# Altium schematic sheet main.SchDoc
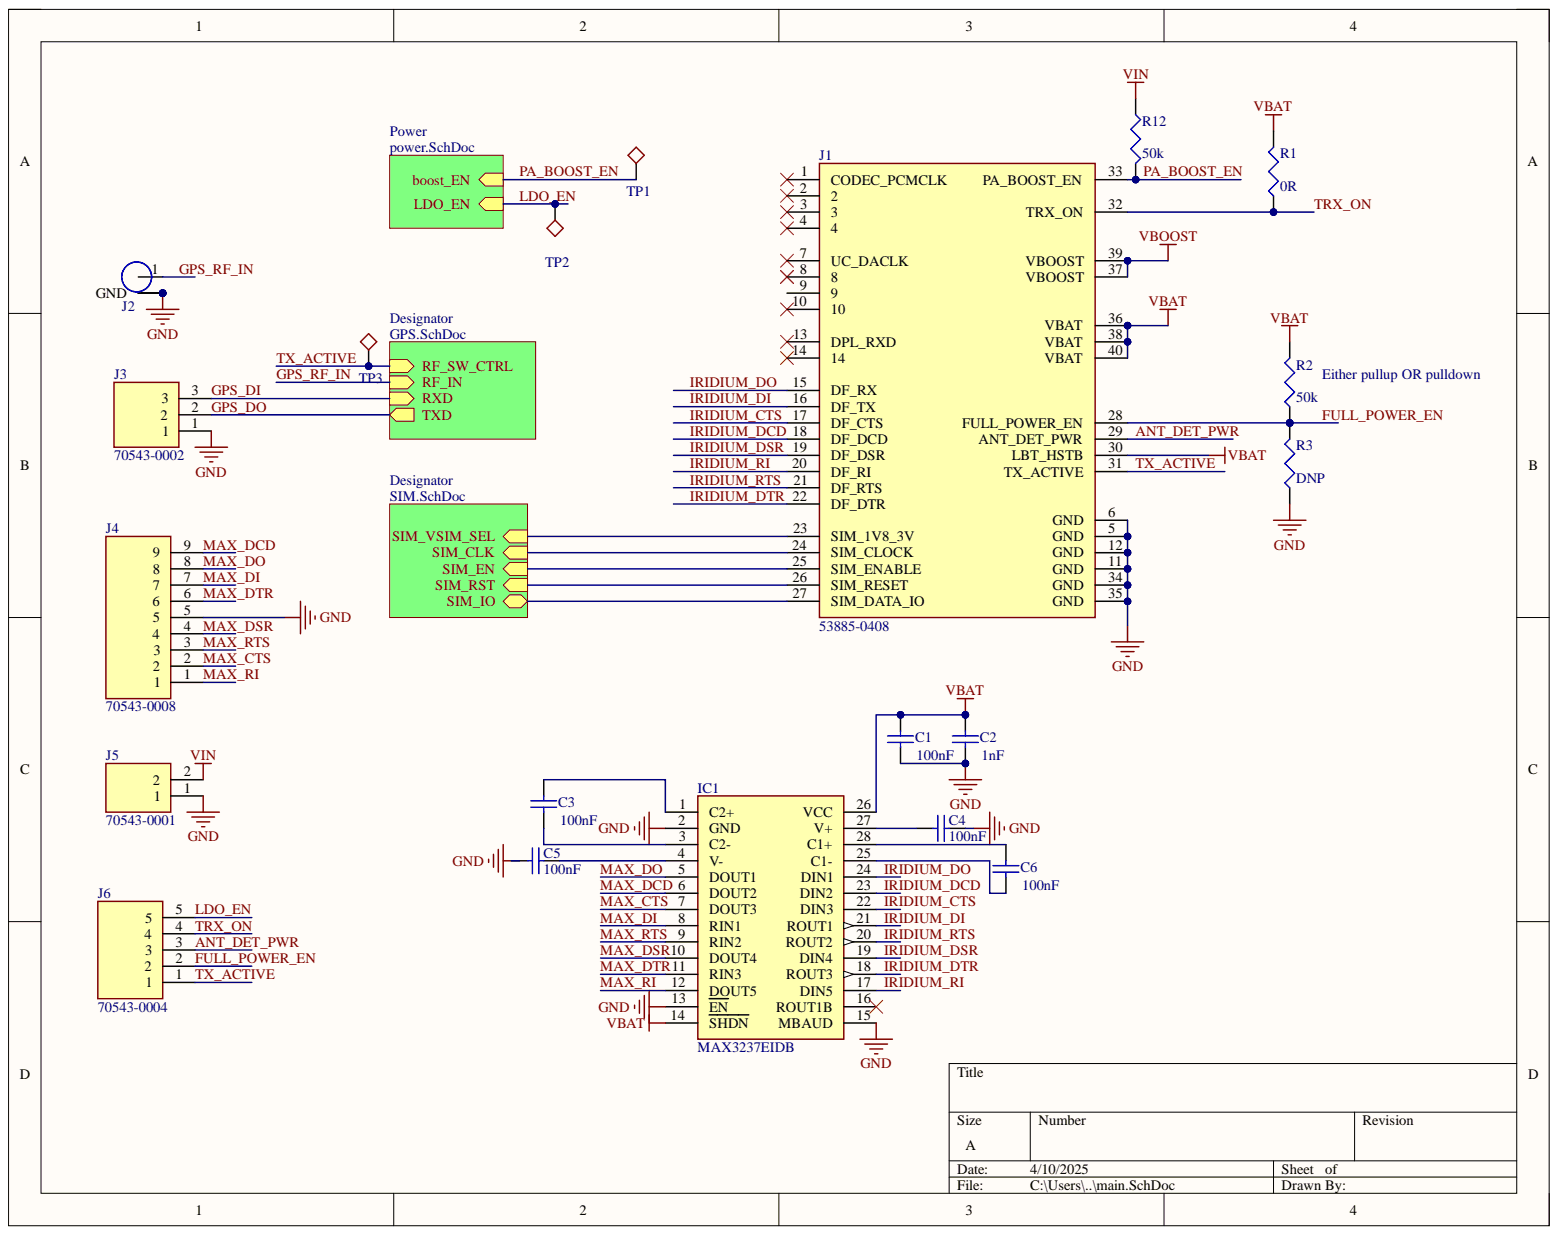
[diagram p0: main.SchDoc]

## Parts
C1  Capacitance: 100nF  [CAPC1608X90X35ML10T15]
C2  Capacitance: 1nF  [CAPC1608X90X35ML10T15]
C3  Capacitance: 100nF  [CAPC1608X90X35ML10T15]
C4  Capacitance: 100nF  [CAPC1608X90X35ML10T15]
C5  Capacitance: 100nF  [CAPC1608X90X35ML10T15]
C6  Capacitance: 100nF  [CAPC1608X90X35ML10T15]
IC1  MAX3237EIDB  [SOP65P780X200-28N]
J1  53885-0408  [538850408]
J2  SMA  [edge_mount_sma]  (value not drawn)
J3  70543-0002  [RHDR3W64P0X254_1X3_1017X660X1194P]
J4  70543-0008  [SHDR9W64P0X254_1X9_2541X660X1181P]
J5  70543-0001  [SHDR2W64P0X254_1X2_737X660X1181P]
J6  70543-0004  [SHDR5W64P0X254_1X5_1525X660X1181P]
R1  value 0R  [J1-0603]
R2  value 50k  [J1-0603]
R3  value DNP  [J1-0603]
R12  value 50k  [J1-0603]
TP1  tp15  [TP15]  (value not drawn)
TP2  tp15  [TP15]  (value not drawn)
TP3  tp15  [TP15]  (value not drawn)

## Nets
GND: C1.1 C2.1 C4.1 C5.2 IC1.2(GND) IC1.13(EN) IC1.15(MBAUD) J1.5(GND) J1.6(GND) J1.11(GND) J1.12(GND) J1.34(GND) J1.35(GND) J2.2 J2.3 J2.4 J2.5 J3.1 J4.5 J5.1 R3.1
VBAT: C1.2 C2.2 IC1.14(SHDN) IC1.26(VCC) J1.30(LBT_HSTB) J1.36(VBAT) J1.38(VBAT) J1.40(VBAT) R1.2 R2.2
VBOOST: J1.37(VBOOST) J1.39(VBOOST)
VIN: J5.2 R12.1
ANT_DET_PWR: J1.29(ANT_DET_PWR) J6.3
FULL_POWER_EN: J1.28(FULL_POWER_EN) J6.2 R2.1 R3.2
GPS_DI: J3.3
GPS_DO: J3.2
GPS_RF_IN: J2.1
IRIDIUM_CTS: IC1.22(DIN3) J1.17(DF_CTS)
IRIDIUM_DCD: IC1.23(DIN2) J1.18(DF_DCD)
IRIDIUM_DI: IC1.21(ROUT1) J1.16(DF_TX)
IRIDIUM_DO: IC1.24(DIN1) J1.15(DF_RX)
IRIDIUM_DSR: IC1.19(DIN4) J1.19(DF_DSR)
IRIDIUM_DTR: IC1.18(ROUT3) J1.22(DF_DTR)
IRIDIUM_RI: IC1.17(DIN5) J1.20(DF_RI)
IRIDIUM_RTS: IC1.20(ROUT2) J1.21(DF_RTS)
LDO_EN: J6.5 TP2.1
MAX_CTS: IC1.7(DOUT3) J4.2
MAX_DCD: IC1.6(DOUT2) J4.9
MAX_DI: IC1.8(RIN1) J4.7
MAX_DO: IC1.5(DOUT1) J4.8
MAX_DSR: IC1.10(DOUT4) J4.4
MAX_DTR: IC1.11(RIN3) J4.6
MAX_RI: IC1.12(DOUT5) J4.1
MAX_RTS: IC1.9(RIN2) J4.3
PA_BOOST_EN: J1.33(PA_BOOST_EN) R12.2 TP1.1
TRX_ON: J1.32(TRX_ON) J6.4 R1.1
TX_ACTIVE: J1.31(TX_ACTIVE) J6.1 TP3.1
NetC3_1: C3.1 IC1.3(C2-)
NetC3_2: C3.2 IC1.1(C2+)
NetC4_2: C4.2 IC1.27(V+)
NetC5_1: C5.1 IC1.4(V-)
NetC6_1: C6.1 IC1.25(C1-)
NetC6_2: C6.2 IC1.28(C1+)
NetIC1_16: IC1.16(ROUT1B)
NetJ1_1: J1.1(CODEC_PCMCLK)
NetJ1_2: J1.2
NetJ1_3: J1.3
NetJ1_4: J1.4
NetJ1_7: J1.7(UC_DACLK)
NetJ1_8: J1.8
NetJ1_9: J1.9
NetJ1_10: J1.10
NetJ1_13: J1.13(DPL_RXD)
NetJ1_14: J1.14
NetJ1_23: J1.23(SIM_1V8_3V)
NetJ1_24: J1.24(SIM_CLOCK)
NetJ1_25: J1.25(SIM_ENABLE)
NetJ1_26: J1.26(SIM_RESET)
NetJ1_27: J1.27(SIM_DATA_IO)

## Library data of the parts
C1-C6 = CMP-2000-04945-1: CAP CER 0603; Rated Voltage: 6.3V; Case Size/Dimension: 0603; Component Kind: Standard; Library Name: Altium Content Vault; Package Description: 2-Pin Surface Mount Device, Body 1.6 x 0.8 mm; Package Reference: 0603
IC1 = MAX3237EIDB: Integrated Circuit; Manufacturer_Name: Texas Instruments; Arrow Part Number: MAX3237EIDB; Arrow Price/Stock: https://www.arrow.com/en/products/max3237eidb/texas-instruments; Component Kind: Standard; Datasheet Link: http://www.ti.com/lit/gpn/MAX3237E; Height: 2mm; Manufacturer_Part_Number: MAX3237EIDB; Mouser Part Number: 595-MAX3237EIDB; Mouser Price/Stock: https://www.mouser.com/Search/Refine.aspx?Keyword=595-MAX3237EIDB; Library Name: Iridium_Module_SIO_sym.SchLib
J1 = 53885-0408: Connector; Manufacturer_Name: Molex; Component Kind: Standard; Datasheet Link: https://componentsearchengine.com/Datasheets/1/53885-0408.pdf; Height: 1.68mm; Manufacturer_Part_Number: 53885-0408; Mouser Part Number: 538-53885-0408; Mouser Price/Stock: https://www.mouser.co.uk/ProductDetail/Molex/53885-0408?qs=OdNwOVlk5%2FhjFAQwfbus0w%3D%3D; Library Name: Iridium_Module_SIO_sym.SchLib
J2 = edge_mount_sma: Component Kind: Standard; Library Name: Iridium_Module_SIO_sym.SchLib
J3 = 70543-0002: Connector; Manufacturer_Name: Molex; Component Kind: Standard; Datasheet Link: https://www.molex.com/pdm_docs/sd/705430002_sd.pdf; Height: 11.94mm; Manufacturer_Part_Number: 70543-0002; Mouser Part Number: 538-70543-0002; Mouser Price/Stock: https://www.mouser.co.uk/ProductDetail/Molex/70543-0002?qs=tYx%252BZc2nXqrtKxtMAO73sw%3D%3D; Library Name: Iridium_Module_SIO_sym.SchLib
J4 = 70543-0008: Connector; Manufacturer_Name: Molex; Component Kind: Standard; Datasheet Link: http://www.farnell.com/datasheets/2698227.pdf; Height: 11.81mm; Manufacturer_Part_Number: 70543-0008; Mouser Part Number: 538-705430008; Mouser Price/Stock: https://www.mouser.co.uk/ProductDetail/Molex/70543-0008?qs=jsoPY5EnoNtxSJGDWBu7Tw%3D%3D; Library Name: Iridium_Module_SIO_sym.SchLib
J5 = 70543-0001: Connector; Manufacturer_Name: Molex; Arrow Part Number: 70543-0001; Arrow Price/Stock: https://www.arrow.com/en/products/70543-0001/molex?region=nac; Component Kind: Standard; Datasheet Link: http://www.molex.com/pdm_docs/sd/705430001_sd.pdf; Height: 11.81mm; Manufacturer_Part_Number: 70543-0001; Mouser Part Number: 538-70543-0001; Mouser Price/Stock: https://www.mouser.co.uk/ProductDetail/Molex/70543-0001?qs=tYx%252BZc2nXqpCsE0XoulATg%3D%3D; Library Name: Iridium_Module_SIO_sym.SchLib
J6 = 70543-0004: Connector; Manufacturer_Name: Molex; Component Kind: Standard; Datasheet Link: https://componentsearchengine.com/Datasheets/2/70543-0004.pdf; Height: 11.81mm; Manufacturer_Part_Number: 70543-0004; Mouser Part Number: 538-70543-0004; Mouser Price/Stock: https://www.mouser.co.uk/ProductDetail/Molex/70543-0004?qs=ZwgtpdmWYYQRmWofVdhxiw%3D%3D; Library Name: Iridium_Module_SIO_sym.SchLib
R1-R3 R12 = Res3: Resistor 0603; Power: 1/16; Component Kind: Standard; Library Name: Miscellaneous Devices.IntLib; PackageDescription: Chip Resistor; PackageReference: J1-0603; Published: 8-Jun-2000; Signal Integrity: Res; Simulation: RESISTOR; Publisher: Altium Limited
TP1-TP3 = tp15: Component Kind: Standard; Library Name: Iridium_Module_SIO_sym.SchLib
